# Altium schematic sheet Power Supply.SchDoc
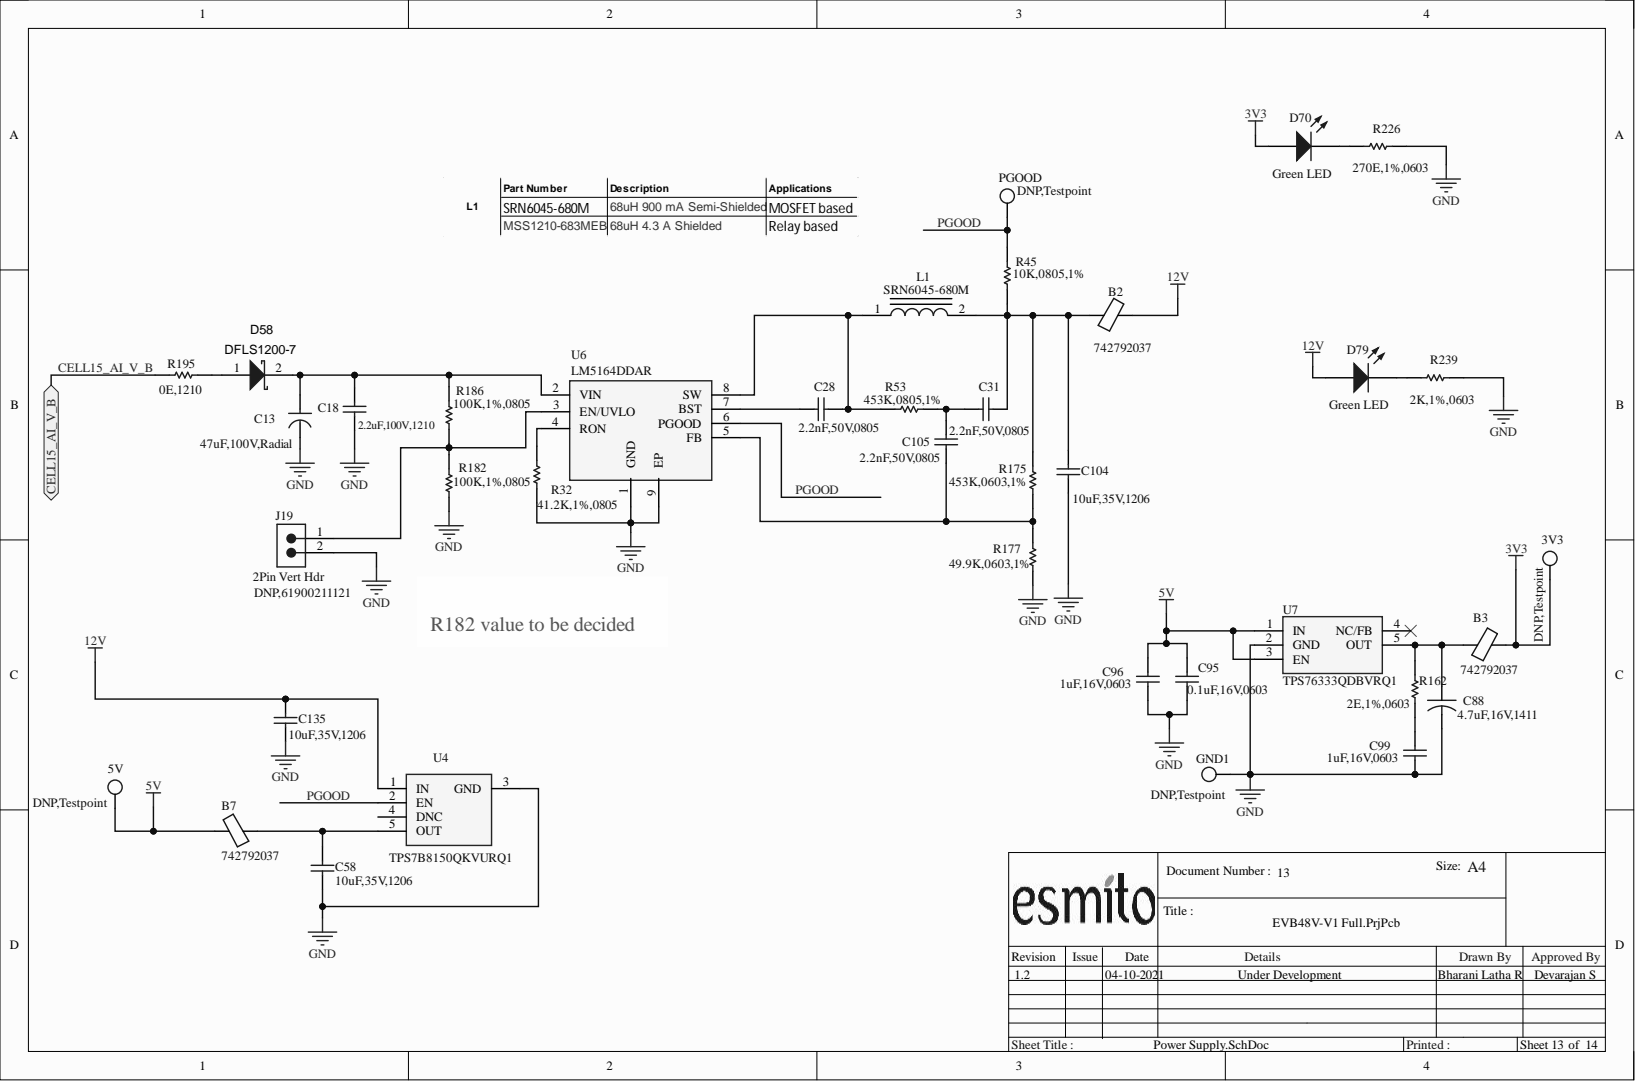
[diagram p0: Power Supply.SchDoc]

## Parts
3V3  value DNP,Testpoint  [Keystone5002]  (hidden comment: TEST POINT)
5V  value DNP,Testpoint  [Keystone5002]  (hidden comment: TEST POINT)
B2  value 742792037  Part Number: 742792037  [0805_R_M]  (hidden comment: B_LowQ)
B3  value 742792037  Part Number: 742792037  [0805_R_M]  (hidden comment: B_LowQ)
B7  value 742792037  Part Number: 742792037  [0805_R_M]  (hidden comment: B_LowQ)
C13  47uF,100V,Radial  [CAPPRD500W60D1050H1750]
C18  value 2.2uF,100V,1210  [1206_C_M]  (hidden comment: C_Semi)
C28  value 2.2nF,50V,0805  [0805_R_M]  (hidden comment: C_Semi)
C31  value 2.2nF,50V,0805  [0805_R_M]  (hidden comment: C_Semi)
C58  value 10uF,35V,1206  [1206_C_M]  (hidden comment: C_Semi)
C88  value 4.7uF,16V,1411  [CAPPM3528X210N]  (hidden comment: CAP)
C95  value 0.1uF,16V,0603  [0603_C_M]  (hidden comment: C_Semi)
C96  value 1uF,16V,0603  [0603_C_M]  (hidden comment: C_Semi)
C99  value 1uF,16V,0603  [0603_C_M]  (hidden comment: C_Semi)
C104  value 10uF,35V,1206  [1206_C_M]  (hidden comment: C_Semi)
C105  value 2.2nF,50V,0805  [0805_R_M]  (hidden comment: C_Semi)
C135  value 10uF,35V,1206  [1206_C_M]  (hidden comment: C_Semi)
D58  DFLS1200-7  [POWERDI123]
D70  value Green LED  [0603_LED_M]  (hidden comment: LED0)
D79  value Green LED  [0603_LED_M]  (hidden comment: LED0)
GND1  value DNP,Testpoint  [Keystone5002]  (hidden comment: TEST POINT)
J19  2Pin Vert Hdr  value DNP,61900211121  [61900211121]
L1  SRN6045-680M  [SRN6045680M_MSS1210-683MEB]
PGOOD  value DNP,Testpoint  [Keystone5002]  (hidden comment: TEST POINT)
R32  value 41.2K,1%,0805  [0805_R_M]  (hidden comment: R_Semi)
R45  value 10K,0805,1%  [0805_R_M]  (hidden comment: R_Semi)
R53  value 453K,0805,1%  [0805_R_M]  (hidden comment: R_Semi)
R162  value 2E,1%,0603  [0603_R_M]  (hidden comment: R_Semi)
R175  value 453K,0603,1%  [0603_R_M]  (hidden comment: R_Semi)
R177  value 49.9K,0603,1%  [0603_R_M]  (hidden comment: R_Semi)
R182  value 100K,1%,0805  [0805_R_M]  (hidden comment: R_Semi)
R186  value 100K,1%,0805  [0805_R_M]  (hidden comment: R_Semi)
R195  value 0E,1210  [1210_R_M]  (hidden comment: R_Semi)
R226  value 270E,1%,0603  [0603_R_M]  (hidden comment: R_Semi)
R239  value 2K,1%,0603  [0603_R_M]  (hidden comment: R_Semi)
U4  TPS7B8150QKVURQ1  [TPS7B8150QKVURQ1]
U6  LM5164DDAR  [SOIC127P600X170-9N]
U7  TPS76333QDBVRQ1  [SOT95P280X145-5N]

## Ports
CELL15_AI_V_B

## Nets
3V3: 3V3.1 B3.2 D70.1
5V: 5V.1 B7.2 C95.1 C96.1 U7.1(IN) U7.3(EN)
12V: B2.2 C135.1 D79.1 U4.1(IN)
GND: C13.2 C18.1 C58.2 C88.2 C95.2 C96.2 C99.2 C104.1 C135.2 GND1.1 J19.2 R32.1 R177.2 R182.1 R226.1 R239.1 U4.3(GND) U6.1(GND) U6.9(EP) U7.2(GND)
CELL15_AI_V_B: R195.2 ; port CELL15_AI_V_B
PGOOD: PGOOD.1 R45.2 U4.2(EN) U6.6(PGOOD)
NetB2_1: B2.1 C31.1 C104.2 L1.2 R45.1 R175.1
NetB3_1: B3.1 C88.1 R162.1 U7.5(OUT)
NetB7_1: B7.1 C58.1 U4.5(OUT)
NetC13_1: C13.1 C18.2 D58.2 R186.2 U6.2(VIN)
NetC28_1: C28.1 L1.1 R53.2 U6.8(SW)
NetC28_2: C28.2 U6.7(BST)
NetC31_2: C31.2 C105.1 R53.1
NetC99_1: C99.1 R162.2
NetC105_2: C105.2 R175.2 R177.1 U6.5(FB)
NetD58_1: D58.1 R195.1
NetD70_2: D70.2 R226.2
NetD79_2: D79.2 R239.2
NetJ19_1: J19.1 R182.2 R186.1 U6.3(EN/UVLO)
NetR32_2: R32.2 U6.4(RON)

Not connected: U4 4(DNC); U7 4(NC/FB)

## Library data of the parts
3V3 5V GND1 PGOOD = T POINT R: PCB TEST POINT BLACK 1mm; Manufacturer: Keystone Electronics; Part Number: 5001; Component Kind: Standard
B2 B3 B7 = B_LowQ: Ferrite Bead WE-CBF 0805 SMD Bead 100MHz 330Ohm 2A; Alternate Manufacturer: Murata; Alternate Part Number: BLM21PG331SN1D; Manufacturer: Wurth Elektronik; Component Kind: Standard; Library Name: Grinntech_Global_Library.IntLib
C13 = ECA-1EM331: Aluminium Electrolytic Capacitor - Radial Leaded 100V 47uF; Manufacturer: Nichicon; Part Number: UPW2A470MPD; Component Kind: Standard; Value: 47uF,100V,Radial; Library Name: AFE_UC_Active_Conn.SchLib
C18 = C_Semi: MLCC - 1210 100V 2.2uF X7R 10%; Manufacturer: TDK; Part Number: C3225X7R2A225K230AB; Component Kind: Standard; Library Name: Grinntech_Global_Library.IntLib
C28 C105 = C_Semi: MLCC - 0805 50V 2.2nF X7R 10%; Manufacturer: KEMET; Part Number: C0805C222K5RACTU; Component Kind: Standard; Signal Integrity: Cap Semi; Simulation: CAP; Library Name: Grinntech_Global_Library.IntLib
C31 = C_Semi: MLCC - 0805 50V 2.2nF X7R 10%; Manufacturer: KEMET; Part Number: C0805C222K5RACTU; Value: 2.2nF,50V,0805; Component Kind: Standard; Signal Integrity: Cap Semi; Simulation: CAP; Library Name: Grinntech_Global_Library.IntLib
C58 C104 C135 = C_Semi: MLCC - 1206 35V 10uF X7R 10%; Manufacturer: TDK; Part Number: C3216X7R1V106K160AC; Component Kind: Standard; Signal Integrity: Cap Semi; Simulation: CAP; Library Name: Grinntech_Global_Library.IntLib
C88 = CAP: TANT 16V 4.7uF 1411 10%; Manufacturer: KEMET; Part Number: T494B475K016; Component Kind: Standard
C95 = C_Semi: MLCC - 0603 100nF 16V X7R 10%; Alternate Manufacturer: AVX; Alternate Part Number: 0603YC104K4T2A; Manufacturer: Yageo; Part Number: CC603KRX7R7BB104; Component Kind: Standard; Signal Integrity: Cap Semi; Simulation: CAP; Library Name: Grinntech_Global_Library.IntLib
C96 C99 = C_Semi: MLCC - 0603 1uF 16V X5R 10%; Alternate Manufacturer: Murata; Alternate Part Number: GCM188R71C105KA64D; Manufacturer: Yageo; Part Number: CC603KRX5R7BB105; Component Kind: Standard; Signal Integrity: Cap Semi; Simulation: CAP; Library Name: Grinntech_Global_Library.IntLib
D58 = DFLS1200-7: Schottky Diode 1.0A 200V POWERDI-123; Manufacturer: Diodes Incorporated; Component Kind: Standard; Part Number: DFLS1200-7; Signal Integrity: Diode; Value: DFLS1200-7; Library Name: CLVESB-R10.SCHLIB
D70 D79 = LED0: Diode, Led, 2V, 20mA, 0603; Alternate Manufacturer: Lite-ON; Alternate Part Number: LTST-C190KGKT; Manufacturer: ROHM; Part Number: SML-D12M8WT86; Component Kind: Standard; Simulation: LED0; Library Name: Grinntech_Global_Library.IntLib
J19 = 61900211121: WR-WTB THT Male Vertical Locking Header, pitch 2.54mm, 2p; Manufacturer: Wurth Elektronik; Component Kind: Standard; Part Number: 61900211121; Library Name: AFE_UC_Active_Conn.SchLib
L1 = SRN6045-680M: Fixed Inductor 68uH Shld 20% 900mA; Alternate Manufacturer: Wurth Elektronik; Alternate Part Number: 74404064680; Manufacturer: Bourns; Component Kind: Standard; Part Number: SRN6045-680M; Value: SRN6045-680M; Library Name: AFE_UC_Active_Conn.SchLib
R32 = R_Semi: R, THK FLM, 41.2K, 1%, 125mW, 0805; Manufacturer: Vishay; Part Number: CRCW080541K2FKEA; Component Kind: Standard; Library Name: Grinntech_Global_Library.IntLib
R45 = R_Semi: Thick Film Resistor - 0805 10K 1% 1/8W; Manufacturer: Vishay; Part Number: AC0805FR-0710KL; Component Kind: Standard; Signal Integrity: Res Semi; Simulation: RES; Library Name: Grinntech_Global_Library.IntLib
R53 = R_Semi: Thick Film Resistor - 0805 1/8W 453K 1%; Manufacturer: Panasonic; Part Number: ERJ-6ENF4533V; Component Kind: Standard; Library Name: Grinntech_Global_Library.IntLib
R162 = R_Semi: R, THK FLM, 2E, 1%, 100mW, 0603; Manufacturer: Yageo; Part Number: RC0603FR-072RL; Component Kind: Standard; Library Name: Grinntech_Global_Library.IntLib
R175 = R_Semi: Thick Film Resistor - 0603 1/10W 453K 1%; Manufacturer: Yageo; Part Number: RC0603FR-07453KL; Component Kind: Standard; Library Name: Grinntech_Global_Library.IntLib
R177 = R_Semi: Thick Film Resistor - 0603 1/10W 49.9K 1%; Manufacturer: Vishay; Part Number: CRCW060349K9FKEA; Component Kind: Standard; Library Name: Grinntech_Global_Library.IntLib
R182 R186 = R_Semi: R, THK FLM, 100K, 1%, 125mW, 0805; Manufacturer: Vishay; Part Number: CRCW0805100KFKEA; Component Kind: Standard; Library Name: Grinntech_Global_Library.IntLib
R195 = R_Semi: R, THK FLM, 0E, 5%, 500mW, 1210; Manufacturer: Yageo; Part Number: AC1210JR-070RL; Component Kind: Standard; Signal Integrity: Res Semi; Simulation: RES; Library Name: Grinntech_Global_Library.IntLib
R226 = R_Semi: R, THK FLM, 270E, 1%, 100mW, 0603; Alternate Manufacturer: KOA; Alternate Part Number: RK73H1JTTD2700F; Manufacturer: Yageo; Part Number: RC0603FR-10270RL; Component Kind: Standard; Signal Integrity: Res Semi; Simulation: RES; Library Name: Grinntech_Global_Library.IntLib
R239 = R_Semi: Thick Film Resistor - 0603 1/10W 2K 1%; Alternate Manufacturer: Vishay; Alternate Part Number: CRCW06032K00FKEA; Manufacturer: Yageo; Part Number: RC0603FR-072KL; Component Kind: Standard; Signal Integrity: Res Semi; Simulation: RES; Library Name: Grinntech_Global_Library.IntLib
U4 = TPS7B8150QKVURQ1: IC REG LINEAR 5V 150MA TO252-5; Manufacturer: Texas Instruments; Component Kind: Standard; Part Number: TPS7B8150QKVURQ1; Value: TPS7B8150QKVURQ1
U6 = LM5163DDAR: Integrated Circuit; Manufacturer: Texas Instruments; Component Kind: Standard; Part Number: LM5164DDAR; Value: LM5164DDAR; Library Name: AFE_UC_Active_Conn.SchLib
U7 = TPS76333QDBVRQ1: Integrated Circuit; Alternate Manufacturer: Texas Instruments; Alternate Part Number: TPS76333QDBVRQ1; Component Kind: Standard; Manufacturer: Texas Instruments; Part Number: TPS76333QDBVRQ1; Value: TPS76333QDBVRQ1; Library Name: AFE_UC_Active_Conn.SchLib
